# Altium schematic sheet Sheet1practice.SchDoc
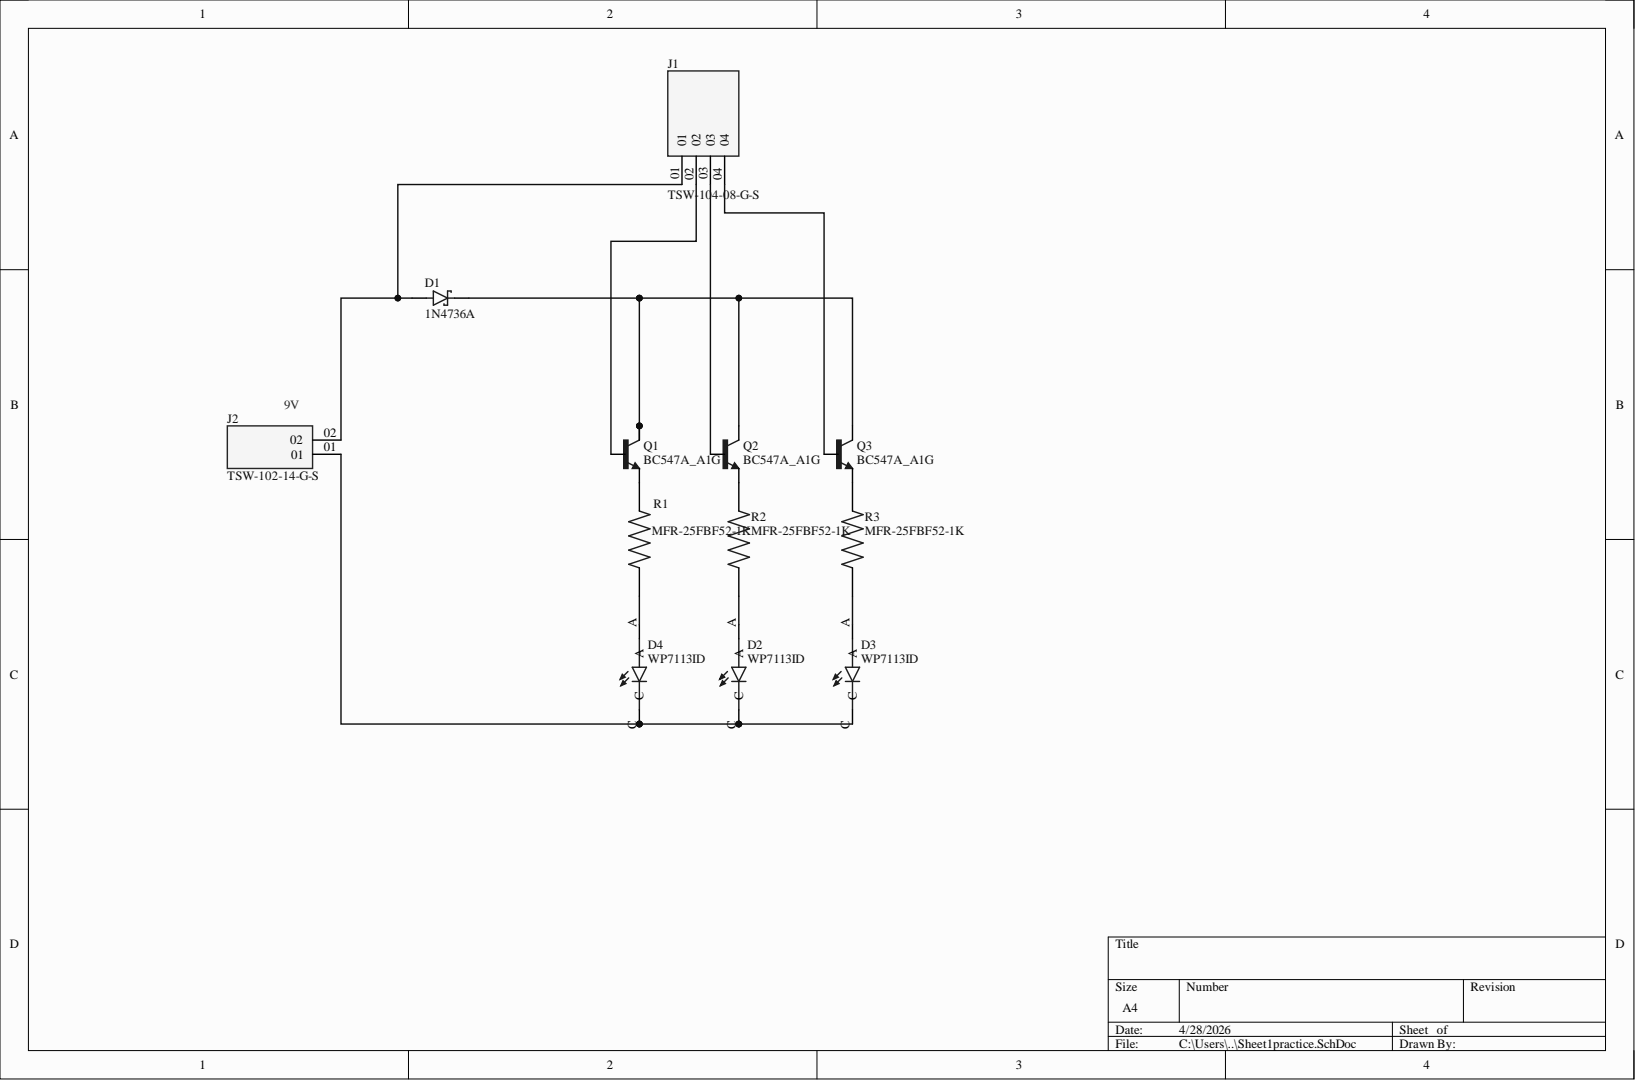
[diagram p0: Sheet1practice.SchDoc]

## Parts
D1  1N4736A  [DIOAD1036W78L463D237]
D2  WP7113ID  [LED_WP7113ID]
D3  WP7113ID  [LED_WP7113ID]
D4  WP7113ID  [LED_WP7113ID]
J1  TSW-104-08-G-S  [SAMTEC_TSW-104-08-G-S]
J2  TSW-102-14-G-S  [SAMTEC_TSW-102-14-G-S]
Q1  BC547A_A1G  [TO92250P510H770-3]
Q2  BC547A_A1G  [TO92250P510H770-3]
Q3  BC547A_A1G  [TO92250P510H770-3]
R1  MFR-25FBF52-1K  [RESAD1100W55L680D260]
R2  MFR-25FBF52-1K  [RESAD1100W55L680D260]
R3  MFR-25FBF52-1K  [RESAD1100W55L680D260]

## Nets
NetD1_1: D1.1 Q1.1 Q2.1 Q3.1
NetD1_2: D1.2 J1.01 J2.02
NetD2_A: D2.A R2.1
NetD2_C: D2.C D3.C D4.C J2.01
NetD3_A: D3.A R3.1
NetD4_A: D4.A R1.1
NetJ1_02: J1.02 Q1.2
NetJ1_03: J1.03 Q2.2
NetJ1_04: J1.04 Q3.2
NetQ1_3: Q1.3 R1.2
NetQ2_3: Q2.3 R2.2
NetQ3_3: Q3.3 R3.2
9V:

## Library data of the parts
D1 = 1N4736A: 1N4736 Series 1 W 6.8 V 10 uA Axial Through Hole Zener Diode - DO-41; MF: ON Semiconductor; Check_prices: https://www.snapeda.com/parts/1N4736A/Onsemi/view-part/?ref=eda; Component Kind: Standard; Library Name: 1N4736A.IntLib; MP: 1N4736A; Package: DO-41 Fairchild Semiconductor; SnapEDA_Link: https://www.snapeda.com/parts/1N4736A/Onsemi/view-part/?ref=snap
D2-D4 = WP7113ID: Red 617nm LED Indication - Discrete 2V Radial; MF: Kingbright; Availability: In Stock; Check_prices: https://www.snapeda.com/parts/WP7113ID/Kingbright/view-part/?ref=eda; Component Kind: Standard; Library Name: WP7113ID.IntLib; MP: WP7113ID; Package: Radial Kingbright; Price: None; Purchase-URL: https://pricing.snapeda.com/search/part/WP7113ID/?ref=eda; SnapEDA_Link: https://www.snapeda.com/parts/WP7113ID/Kingbright/view-part/?ref=snap
Q1-Q3 = BC547A_A1G: TO-92, 50V, 0.1A, NPN Bipolar Transistor; MF: Taiwan Semiconductor Corporation; MP: BC547A A1G; Availability: In Stock; Check_prices: https://www.snapeda.com/parts/BC547A%20A1G/Taiwan+Semiconductor/view-part/?ref=eda; Component Kind: Standard; Library Name: BC547A_A1G.IntLib; Package: TO-92 Taiwan Semiconductor; Price: None; Purchase-URL: https://pricing.snapeda.com/search/part/BC547A A1G/?ref=eda; SnapEDA_Link: https://www.snapeda.com/parts/BC547A%20A1G/Taiwan+Semiconductor/view-part/?ref=snap
R1-R3 = MFR-25FBF52-1K: 1.07 kOhms Â±1% 0.25W, 1/4W Through Hole Resistor Axial - Metal Film; MF: Yageo; Check_prices: https://www.snapeda.com/parts/MFR-25FBF52-1K/Yageo/view-part/?ref=eda; Component Kind: Standard; Library Name: MFR-25FBF52-1K.IntLib; MP: MFR-25FBF52-1K; Package: RADIAL-2 YAGEO; SnapEDA_Link: https://www.snapeda.com/parts/MFR-25FBF52-1K/Yageo/view-part/?ref=snap
J1 = TSW-104-08-G-S: Availability: Unavailable; Component Kind: Standard; Library Name: TSW-104-08-G-S.IntLib; MP: TSW-104-08-G-S; Package: None; Price: None
J2 = TSW-102-14-G-S: Availability: Unavailable; Component Kind: Standard; Library Name: TSW-102-14-G-S.IntLib; MP: TSW-102-14-G-S; Package: None; Price: None
